# Altium schematic sheet Power.SchDoc(Power)
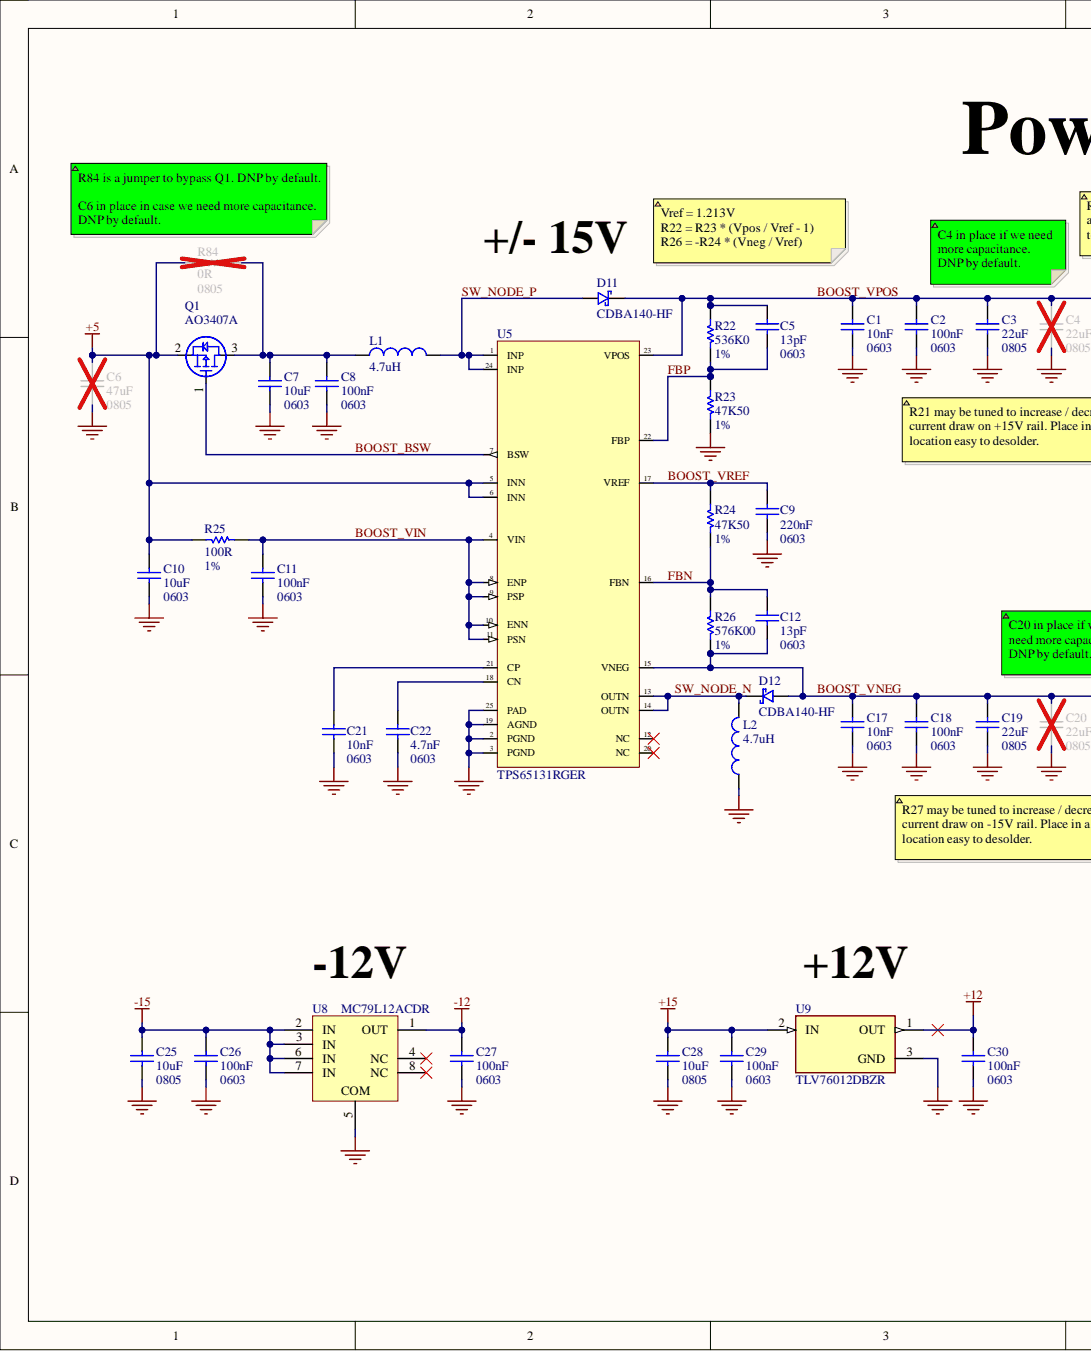
[diagram p0: Power.SchDoc, left]
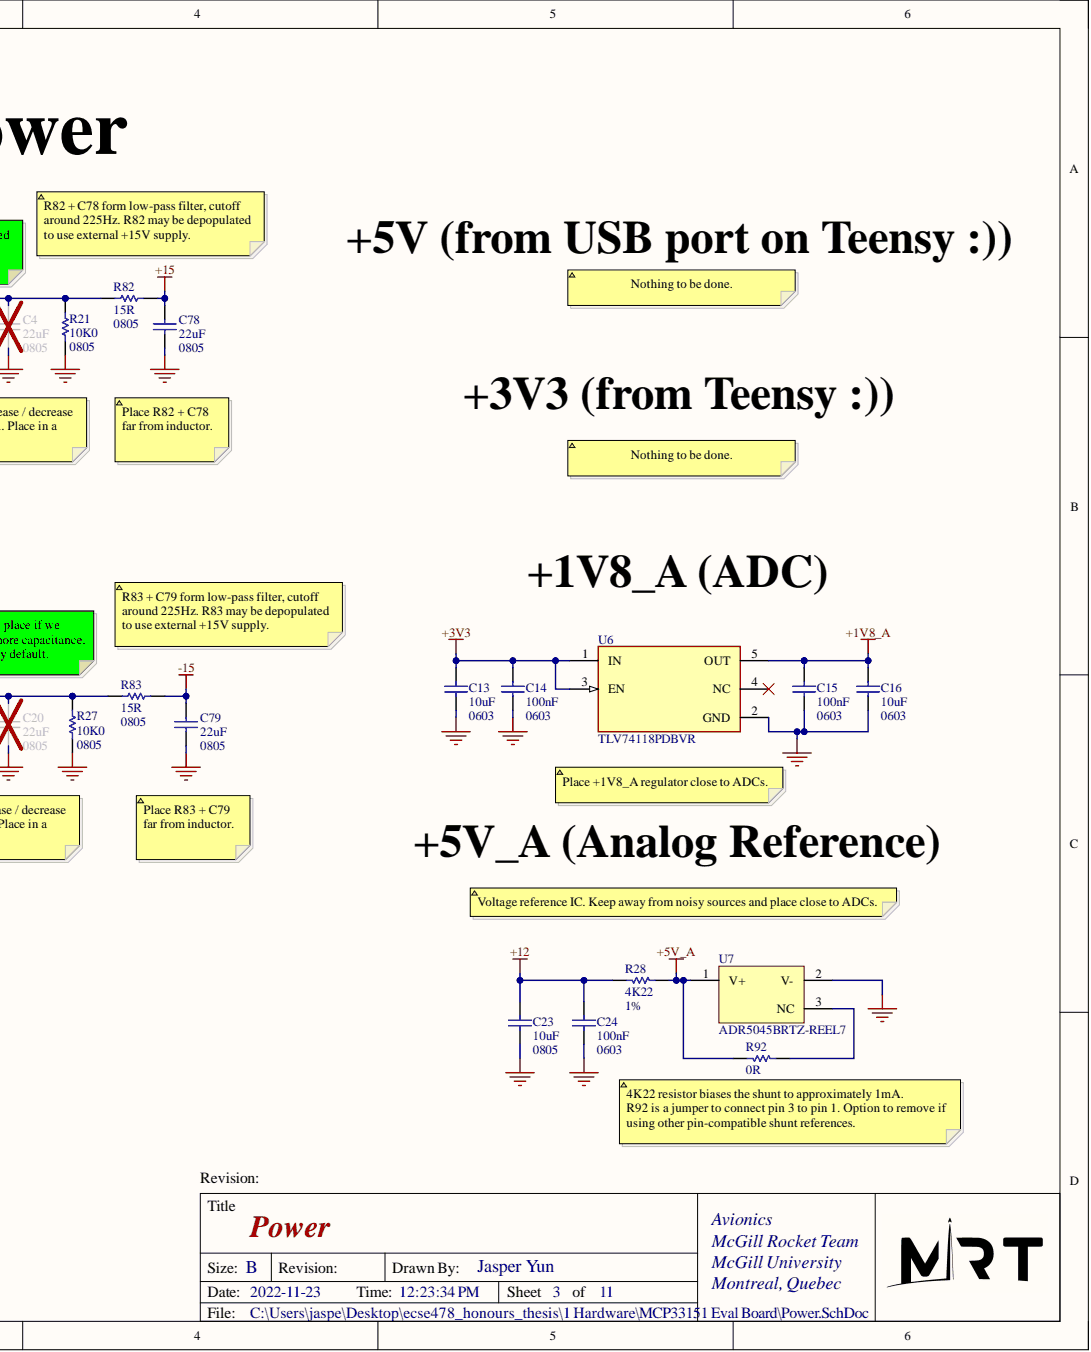
[diagram p1: Power.SchDoc, right]

## Parts
C1  10nF  value 0603  [0603 CAP SAMSUNG CL10C]
C2  100nF  value 0603  [0603 CAP SAMSUNG CL10C]
C3  22uF  value 0805  [0805 CAP]
C4  22uF  value 0805  [0805 CAP]
C5  13pF  value 0603  [0603 CAP SAMSUNG CL10C]
C6  47uF  value 0805  [0805 CAP]
C7  10uF  value 0603  [0603 CAP SAMSUNG CL10C]
C8  100nF  value 0603  [0603 CAP SAMSUNG CL10C]
C9  220nF  value 0603  [0603 CAP SAMSUNG CL10C]
C10  10uF  value 0603  [0603 CAP SAMSUNG CL10C]
C11  100nF  value 0603  [0603 CAP SAMSUNG CL10C]
C12  13pF  value 0603  [0603 CAP SAMSUNG CL10C]
C13  10uF  value 0603  [0603 CAP SAMSUNG CL10C]
C14  100nF  value 0603  [0603 CAP SAMSUNG CL10C]
C15  100nF  value 0603  [0603 CAP SAMSUNG CL10C]
C16  10uF  value 0603  [0603 CAP SAMSUNG CL10C]
C17  10nF  value 0603  [0603 CAP SAMSUNG CL10C]
C18  100nF  value 0603  [0603 CAP SAMSUNG CL10C]
C19  22uF  value 0805  [0805 CAP]
C20  22uF  value 0805  [0805 CAP]
C21  10nF  value 0603  [0603 CAP SAMSUNG CL10C]
C22  4.7nF  value 0603  [0603 CAP SAMSUNG CL10C]
C23  10uF  value 0805  [0805 CAP]
C24  100nF  value 0603  [0603 CAP SAMSUNG CL10C]
C25  10uF  value 0805  [0805 CAP]
C26  100nF  value 0603  [0603 CAP SAMSUNG CL10C]
C27  100nF  value 0603  [0603 CAP SAMSUNG CL10C]
C28  10uF  value 0805  [0805 CAP]
C29  100nF  value 0603  [0603 CAP SAMSUNG CL10C]
C30  100nF  value 0603  [0603 CAP SAMSUNG CL10C]
C78  22uF  value 0805  [0805 CAP]
C79  22uF  value 0805  [0805 CAP]
D11  CDBA140-HF  [Diode SMA]
D12  CDBA140-HF  [Diode SMA]
L1  4.7uH  [Inductor BWVS005050403R3M00]
L2  4.7uH  [Inductor BWVS005050403R3M00]
Q1  AO3407A  [SOT23-3]
R21  10K0  value 0805  [0805 RES]
R22  536K0  value 1%  [0603 RES]
R23  47K50  value 1%  [0603 RES]
R24  47K50  value 1%  [0603 RES]
R25  100R  value 1%  [0603 RES]
R26  576K00  value 1%  [0603 RES]
R27  10K0  value 0805  [0805 RES]
R28  4K22  value 1%  [0603 RES]
R82  15R  value 0805  [0805 RES]
R83  15R  value 0805  [0805 RES]
R84  0R  value 0805  [0805 RES]
R92  0R  [0603 RES]
U5  TPS65131RGER  [VQFN-24]
U6  TLV74118PDBVR  [FP-DBV0005A-IPC_A]
U7  ADR5045BRTZ-REEL7  [ADI-RT-3_M]
U8  MC79L12ACDR  [D0008A_M]
U9  TLV76012DBZR  [FP-DBZ0003A-IPC_A]

## Nets
+1V8_A: C15.2 C16.2 U6.5(OUT)
+3V3: C13.2 C14.2 U6.1(IN) U6.3(EN)
+5: C6.2 C10.2 Q1.2 R25.1 R84.2 U5.5(INN) U5.6(INN)
+5V_A: R28.2 R92.1 U7.1
+12: C23.2 C24.2 C30.2 R28.1 U9.1
+15: C28.2 C29.2 C78.2 R82.1 U9.2
-12: C27.2 U8.1(OUT)
-15: C25.2 C26.2 C79.2 R83.1 U8.2(IN) U8.3(IN) U8.6(IN) U8.7(IN)
GND: C1.1 C2.1 C3.1 C4.1 C6.1 C7.1 C8.1 C9.2 C10.1 C11.1 C13.1 C14.1 C15.1 C16.1 C17.1 C18.1 C19.1 C20.1 C21.1 C22.1 C23.1 C24.1 C25.1 C26.1 C27.1 C28.1 C29.1 C30.1 C78.1 C79.1 L2.1 R21.1 R23.2 R27.1 U5.2(PGND) U5.3(PGND) U5.19(AGND) U5.25(PAD) U6.2(GND) U7.2 U8.5 U9.3
BOOST_BSW: Q1.1 U5.7(BSW)
BOOST_VIN: C11.2 R25.2 U5.4(VIN) U5.8(ENP) U5.9(PSP) U5.10(ENN) U5.11(PSN)
BOOST_VNEG: C12.1 C17.2 C18.2 C19.2 C20.2 D12.2 R26.1 R27.2 R83.2 U5.15(VNEG)
BOOST_VPOS: C1.2 C2.2 C3.2 C4.2 C5.1 D11.1 R21.2 R22.1 R82.2 U5.23(VPOS)
BOOST_VREF: C9.1 R24.1 U5.17(VREF)
FBN: C12.2 R24.2 R26.2 U5.16(FBN)
FBP: C5.2 R22.2 R23.1 U5.22(FBP)
SW_NODE_N: D12.1 L2.2 U5.13(OUTN) U5.14(OUTN)
SW_NODE_P: D11.2 L1.2 U5.1(INP) U5.24(INP)
NetC7_2: C7.2 C8.2 L1.1 Q1.3 R84.1
NetC21_2: C21.2 U5.21(CP)
NetC22_2: C22.2 U5.18(CN)
NetR92_2: R92.2 U7.3

Not connected: U5 12(NC) 20(NC); U6 4(NC); U8 4(NC) 8(NC)

## Library data of the parts
C1-C30 C78 C79 = Cap: Capacitor; Component Kind: Standard; LatestRevisionDate: 17-Jul-2002; LatestRevisionNote: Re-released for DXP Platform.; Library Name: Miscellaneous Devices.IntLib; PackageDescription: Radial Cap, Thru-Hole; 2 Leads; 0.3 in Pin Spacing; PackageReference: RAD-0.3; Published: 8-Jun-2000; Signal Integrity: Cap; Simulation: CAP; Publisher: Altium Limited
D11 D12 = Schottky Diode: Generic Schottky Diode; Component Kind: Standard; Library Name: MRT SCH Library.SchLib
L1 L2 = Inductor: 4.7uH  BWVS005050404R7M00; Code_IEC: 1005; Code_JEITA: 0402; Component Kind: Standard; LatestRevisionDate: 29-May-2009; LatestRevisionNote: IPC-7351 Footprint Added.; Library Name: Miscellaneous Devices.IntLib; PackageDescription: Chip Inductor; PackageReference: 0402-A; Published: 8-Jun-2000; Signal Integrity: Inductor; Simulation: INDUCTOR; Value: Value; Publisher: Altium Limited
Q1 = PMOS: AO3407A PMOS; Component Kind: Standard; Library Name: MRT SCH Library.SchLib
R21-R28 R82 R83 = Res1: Resistor; Component Kind: Standard; LatestRevisionDate: 17-Jul-2002; LatestRevisionNote: Re-released for DXP Platform.; Library Name: Miscellaneous Devices.IntLib; PackageDescription: Axial Device, Thru-Hole; 2 Leads; 0.3 in Pin Spacing; PackageReference: AXIAL-0.3; Published: 8-Jun-2000; Signal Integrity: Res; Simulation: RESISTOR; Publisher: Altium Limited
R84 = Res1: Resistor; Value: 0805; Component Kind: Standard; LatestRevisionDate: 17-Jul-2002; LatestRevisionNote: Re-released for DXP Platform.; Library Name: Miscellaneous Devices.IntLib; PackageDescription: Axial Device, Thru-Hole; 2 Leads; 0.3 in Pin Spacing; PackageReference: AXIAL-0.3; Published: 8-Jun-2000; Signal Integrity: Res; Simulation: RESISTOR; Publisher: Altium Limited
R92 = Res1: Resistor; Value: 1%; Component Kind: Standard; LatestRevisionDate: 17-Jul-2002; LatestRevisionNote: Re-released for DXP Platform.; Library Name: Miscellaneous Devices.IntLib; PackageDescription: Axial Device, Thru-Hole; 2 Leads; 0.3 in Pin Spacing; PackageReference: AXIAL-0.3; Published: 8-Jun-2000; Signal Integrity: Res; Simulation: RESISTOR; Publisher: Altium Limited
U5 = Dual Boost TPS65131RGER: IC REG BUCK BST INV ADJ DL 24QFN; Manufacturer: Texas Instruments; Efficiency: 85%; Max Input Voltage: 5.5V; Max Output Voltage: 15V; Min Input Voltage: 2.7V; Min Output Voltage: -15V; Number of Outputs: 2; Output Current: 1.95A; Output Type: Adjustable; Quiescent Current: 500uA; Switching Frequency: 1.4MHz; Synchronous Rectifier: No; Topology: Buck-Boost; Case/Package: VQFN EP; Category: Voltage Regulators - Switching; Component Kind: Standard; ComponentLink1Description: https://datasheet.ciiva.com/4119/40762122s8213930n7713-4119696.pdf?src-supplier=Arrow+Electronics; ComponentLink1URL: https://datasheet.ciiva.com/4119/40762122s8213930n7713-4119696.pdf?src-supplier=Arrow+Electronics; ComponentLink2Description: https://datasheet.ciiva.com/14378/tps65130-14378346.pdf?src-supplier=Avnet; ComponentLink2URL: https://datasheet.ciiva.com/14378/tps65130-14378346.pdf?src-supplier=Avnet; ComponentLink3Description: https://datasheet.ciiva.com/15223/getdatasheetpartid-254483-15223079.pdf?src-supplier=Verical; ComponentLink3URL: https://datasheet.ciiva.com/15223/getdatasheetpartid-254483-15223079.pdf?src-supplier=Verical; ComponentLink4Description: https://datasheet.ciiva.com/2035/tps65130-2035332.pdf?src-supplier=Digi-Key; ComponentLink4URL: https://datasheet.ciiva.com/2035/tps65130-2035332.pdf?src-supplier=Digi-Key; Lead Free: Lead Free; Manufacturer Part Number: TPS65131RGER; Max Operating Temperature: 85°C; Min Operating Temperature: -40°C; Number of Pins: 24; Operating Supply Voltage: 5.5V; Output Voltage: 15V; Package Quantity: 3000; Packaging: Tape and Reel; Pricing 1: 1=3.00000, 10=2.69400, 25=2.54720, 100=2.20760, 250=2.09436, 500=1.87926, 1000=1.70880 (USD); Radiation Hardening: No; RoHS: Yes; RoHS Compliant: Yes; Series: -; Stock 1: 7644; Supplier 1: Digi-Key; Supplier Part Number 1: 296-41760-1-ND; Weight: 0.001524oz; Library Name: MRT SCH Library.SchLib
U6 = CMP-04917-000111-2: None; Dropout Voltage: 695mV; Max Input Voltage: 5.5V; Max Supply Current: 75uA; Min Output Voltage: 1.8V; Number of Regulators: 1; Output Configuration: Positive; Output Current: 150mA; Output Type: Fixed; Power Supply Rejection Ratio (PSRR): 55dB; Quiescent Current: 50uA; Case/Package: SOT-23-5; Component Kind: Standard; Library Name: Altium Content Vault; Max Operating Temperature: 125°C; Min Operating Temperature: -40°C; Mount: Surface Mount; Packaging: Cut Tape; RoHS Compliant: Yes; Simulation: TLV74133P_TRANS
U7 = CMP-0784-00426-1: Precision Micropower Shunt Mode Voltage Reference, 5 V Output, Industrial, 3-pin SOT23 (RT-3), Reel; Manufacturer: Analog Devices; Ref Out TC (ppm/C): 10; Vout: 5V; Code_JEDEC: TO-236-AB; Component Kind: Standard; ComponentLink1Description: Manufacturer URL; ComponentLink1URL: http://www.analog.com/en/index.html; ComponentLink2Description: Datasheet; ComponentLink2URL: http://www.analog.com/static/imported-files/data_sheets/ADR5040_5041_5043_5044_5045.pdf; ComponentLink3Description: Package Specification; ComponentLink3URL: http:/www.analog.com/static/imported-files/packages/PKG_PDF/SOT-23-3 (RT)/RT_3.pdf; ComponentLink4Description: URL Link1; ComponentLink4URL: Product URL:http://www.analog.com/en/special-linear-functions/voltage-references/adr5045/products/product.html; DatasheetVersion: Rev. B; Library Name: Altium Content Vault; Mounting Technology: Surface Mount; Operating Temp Range: -40 to 125 degC; PackageDescription: 3-Pin Small Outline Transistor Package, Body 2.9 x 1.3 mm, Pitch 0.96 mm; PackageReference: RT-3; PackageVersion: 011909-C, 02/2009; Packing: Reel; PartNumber: ADR5045BRTZ-REEL7
U8 = CMP-0324-00120-3: Fixed Regulator with -14.5 to -27 V Input and -12 V Output, 0 to 125 degC, 8-Pin SOIC (D), Green (RoHS & no Sb/Br); Manufacturer: Texas Instruments; Iout(Max)(A): 0.1; Iq(Typ)(mA): 6.5; Code_JEDEC: MS-012AA; Component Kind: Standard; ComponentLink1Description: Datasheet; ComponentLink1URL: http://www.ti.com/general/docs/lit/getliterature.tsp?genericPartNumber=MC79L12A&fileType=pdf; ComponentLink2Description: Package Specification; ComponentLink2URL: http://www.ti.com/litv/pdf/msoi002j; ComponentLink3Description: URL Link1; ComponentLink3URL: Samples URL:http://www.ti.com/product/mc79l12a#samples; DatasheetVersion: SLVS011D; Eco-Plan: Green (RoHS & no Sb/Br); Library Name: Altium Content Vault; Mounting Technology: Surface Mount; PackageDescription: 8-Pin Plastic Small Outline Integrated Circuit, 4.9 x 3.9 mm, Pitch 1.27 mm Pitch; PackageReference: D0008A; PackageVersion: revM, Jun-2011; PartNumber: MC79L12ACDR; Vin(Max)(V): -27; Vin(Min)(V): -14.5; Vout(Max)(V): -12; Vout(Min)(V): -12
U9 = CMP-04917-000204-1: IC REG LINEAR 12V 100MA SOT23-3; Dropout Voltage: 1.1V; Max Input Voltage: 30V; Number of Regulators: 1; Output Configuration: Positive; Output Type: Fixed; Quiescent Current: 5mA; Case/Package: TO-236-3; Component Kind: Standard; Library Name: Altium Content Vault; Max Operating Temperature: 125°C; Min Operating Temperature: -40°C; Min Output Voltage: 12V; Mount: Surface Mount; Output Current: 100mA; Packaging: Cut Tape; RoHS Compliant: Yes
